# Altium schematic sheet 05 - ETHERNET, USB.SchDoc("05 - ETHERNET, USB")
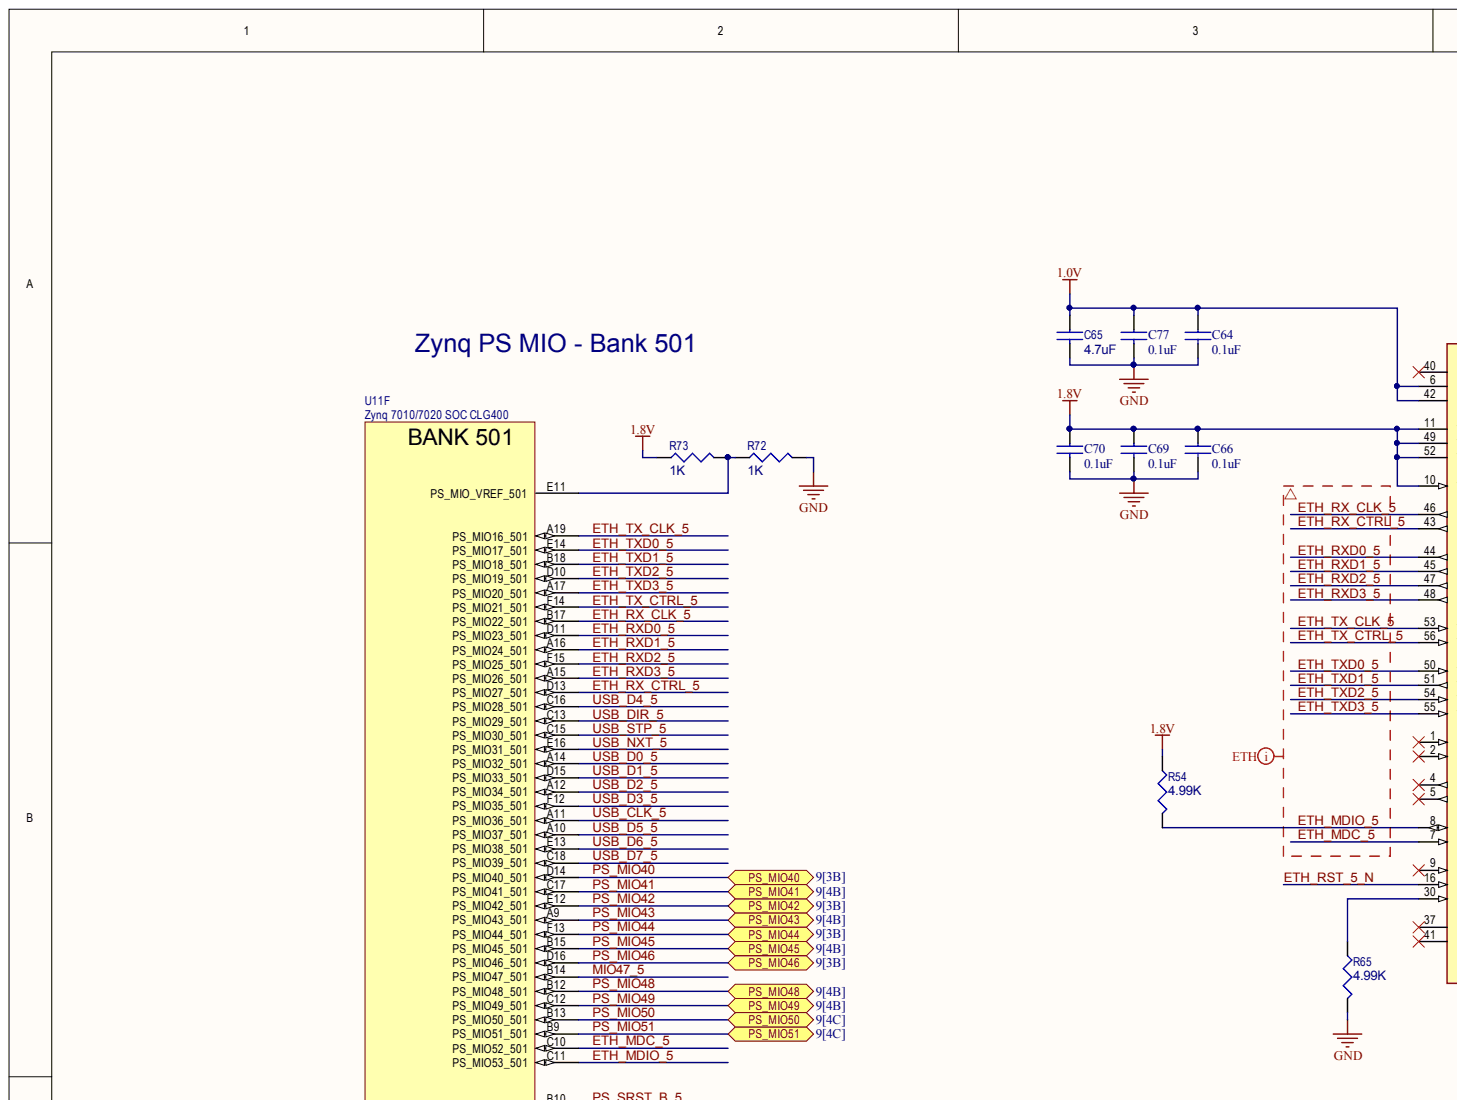
[diagram p0: 05 - ETHERNET, USB.SchDoc, top left]
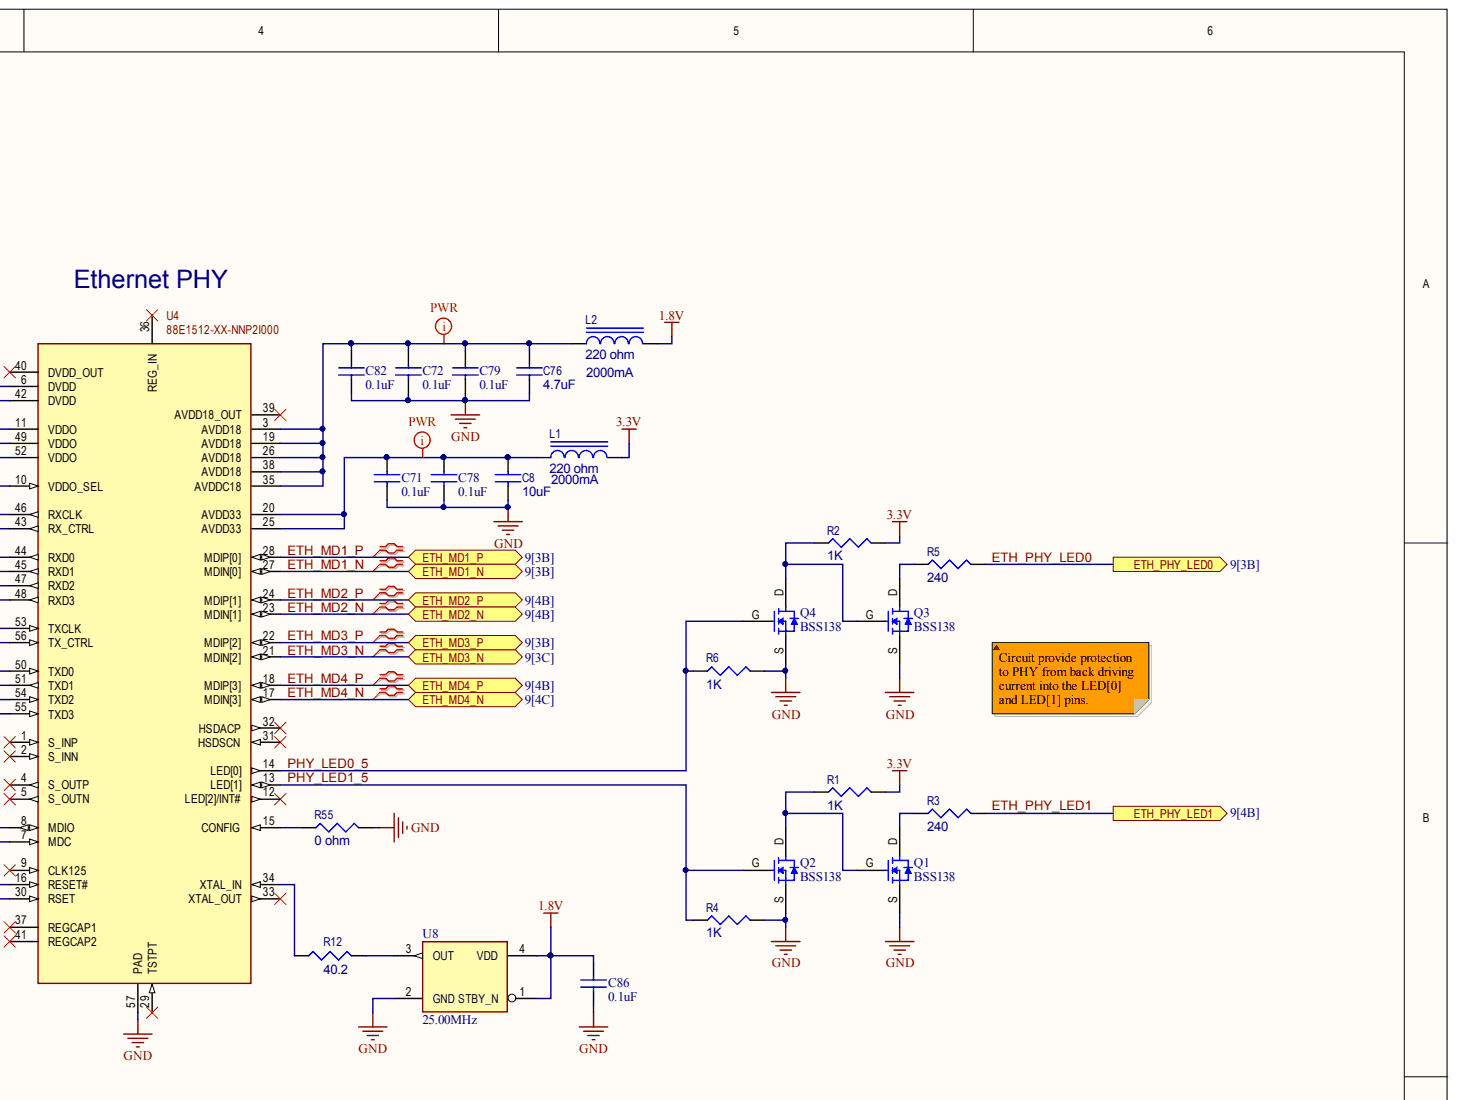
[diagram p1: 05 - ETHERNET, USB.SchDoc, top right]
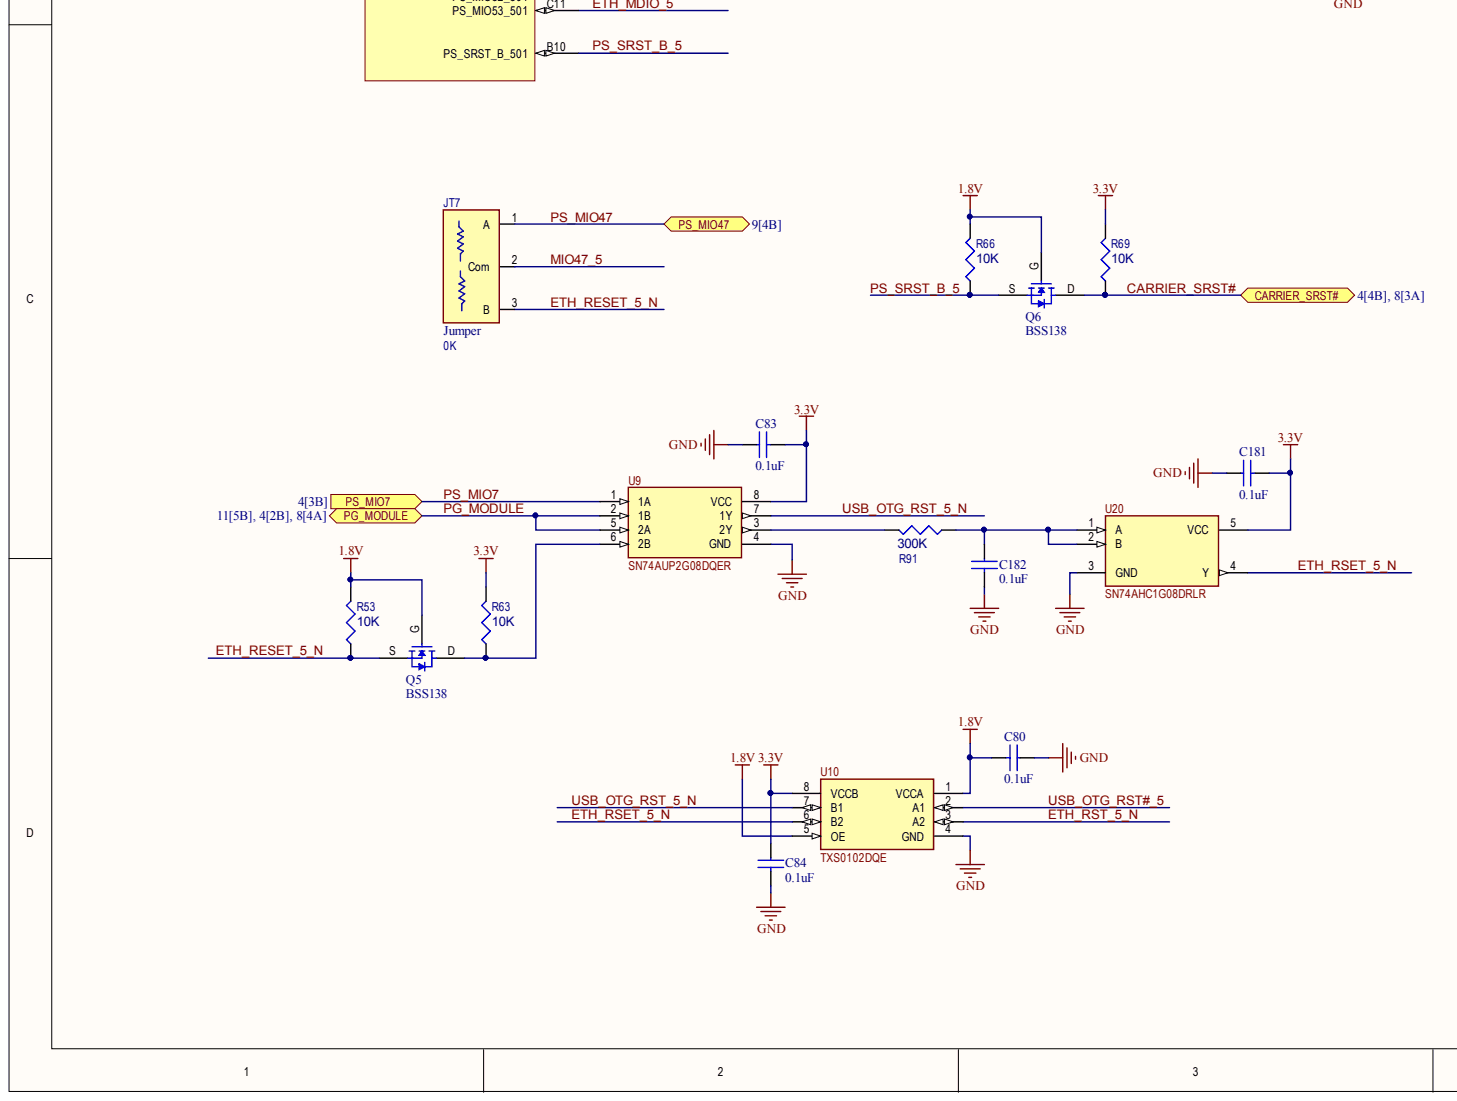
[diagram p2: 05 - ETHERNET, USB.SchDoc, bottom left]
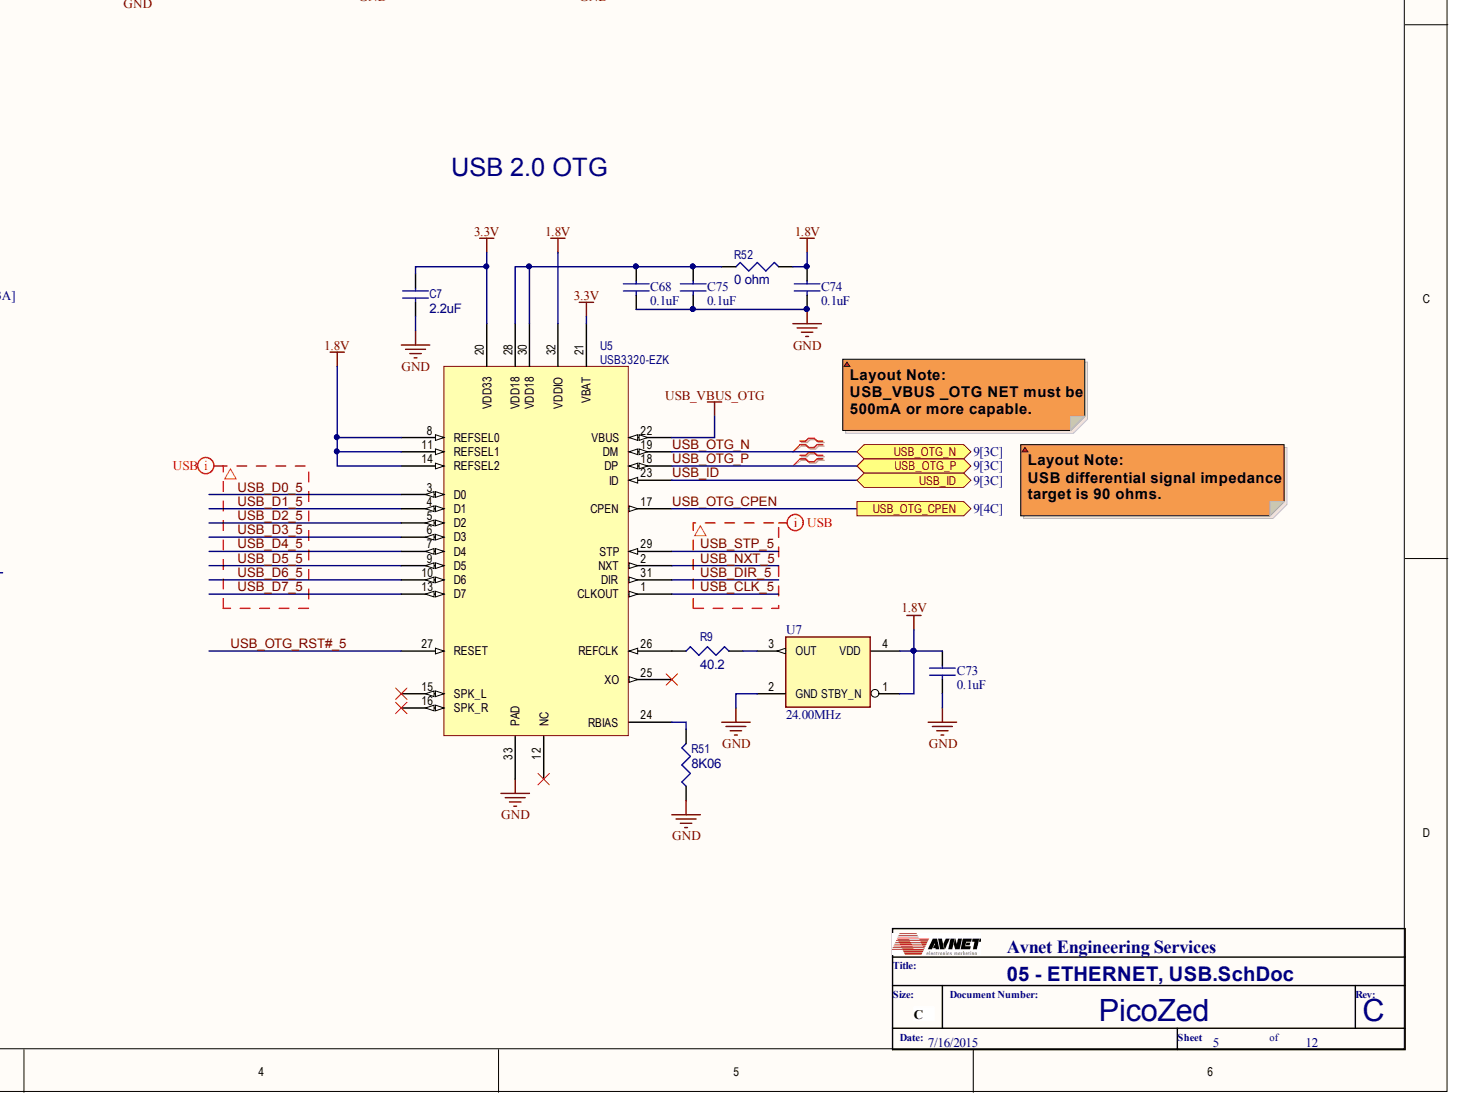
[diagram p3: 05 - ETHERNET, USB.SchDoc, bottom right]

## Parts
C7  2.2uF  [C0603]
C8  10uF  [C0603]
C64  value 0.1uF  [C0201]  (hidden comment: PC522)
C65  4.7uF  [C0402]
C66  value 0.1uF  [C0201]  (hidden comment: PC522)
C68  value 0.1uF  [C0201]  (hidden comment: PC522)
C69  value 0.1uF  [C0201]  (hidden comment: PC522)
C70  value 0.1uF  [C0201]  (hidden comment: PC522)
C71  value 0.1uF  [C0201]  (hidden comment: PC522)
C72  value 0.1uF  [C0201]  (hidden comment: PC522)
C73  value 0.1uF  [C0201]  (hidden comment: PC522)
C74  value 0.1uF  [C0201]  (hidden comment: PC522)
C75  value 0.1uF  [C0201]  (hidden comment: PC522)
C76  4.7uF  [C0402]
C77  value 0.1uF  [C0201]  (hidden comment: PC522)
C78  value 0.1uF  [C0201]  (hidden comment: PC522)
C79  value 0.1uF  [C0201]  (hidden comment: PC522)
C80  value 0.1uF  [C0201]  (hidden comment: PC522)
C82  value 0.1uF  [C0201]  (hidden comment: PC522)
C83  value 0.1uF  [C0201]  (hidden comment: PC522)
C84  value 0.1uF  [C0201]  (hidden comment: PC522)
C86  value 0.1uF  [C0201]  (hidden comment: PC522)
C181  value 0.1uF  [C0201]  (hidden comment: PC522)
C182  value 0.1uF  [C0201]  (hidden comment: PC522)
JT7  value 0K  Device: Jumper  [R0402-DUAL_JMP]
L1  value 220 ohm  Imax: 2000mA  [L0805]  (hidden comment: PF009)
L2  value 220 ohm  Imax: 2000mA  [L0805]  (hidden comment: PF009)
Q1  BSS138  [SOT23GSD]
Q2  BSS138  [SOT23GSD]
Q3  BSS138  [SOT23GSD]
Q4  BSS138  [SOT23GSD]
Q5  BSS138  [SOT23GSD]
Q6  BSS138  [SOT23GSD]
R1  1K  [R0402]
R2  1K  [R0402]
R3  240  [R0402]
R4  1K  [R0402]
R5  240  [R0402]
R6  1K  [R0402]
R9  40.2  [R0402]
R12  40.2  [R0402]
R51  8K06  [R0402]
R52  0 ohm  [R0402]
R53  10K  [R0402]
R54  4.99K  [R0402]
R55  0 ohm  [R0402]
R63  10K  [R0402]
R65  4.99K  [R0402]
R66  10K  [R0402]
R69  10K  [R0402]
R72  1K  [R0402]
R73  1K  [R0402]
R91  300K  [R0402]
U4  88E1512-XX-NNP2I000  [QFN56_14X14]
U5  USB3320-EZK  [QFN32]
U7  24.00MHz  [XTL_DSC1001-2.5X2.0]
U8  25.00MHz  [XTL_DSC1001-2.5X2.0]
U9  SN74AUP2G08DQER  [DQE8]
U10  TXS0102DQE  [DQE8]
U11  Zynq 7010/7020 SOC CLG400  [BGA400C80P20X20_1700X1700X160]  (part F)
U20  SN74AHC1G08DRLR  [SOT553]

## Ports
CARRIER_SRST#, ETH_MD1_N, ETH_MD1_P, ETH_MD2_N, ETH_MD2_P, ETH_MD3_N, ETH_MD3_P, ETH_MD4_N, ETH_MD4_P, ETH_PHY_LED0, ETH_PHY_LED1, PG_MODULE, PS_MIO7, PS_MIO40, PS_MIO41, PS_MIO42, PS_MIO43, PS_MIO44, PS_MIO45, PS_MIO46, PS_MIO47, PS_MIO48, PS_MIO49, PS_MIO50, PS_MIO51, USB_ID, USB_OTG_CPEN, USB_OTG_N, USB_OTG_P

## Nets
1.0V: C64.1 C65.1 C77.1 U4.6(DVDD) U4.42(DVDD)
1.8V: C66.1 C69.1 C70.1 C73.1 C74.1 C80.2 C86.1 L2.2 Q5.G Q6.G R52.2 R53.2 R54.1 R66.2 R73.2 U4.10(VDDO_SEL) U4.11(VDDO) U4.49(VDDO) U4.52(VDDO) U5.8(REFSEL0) U5.11(REFSEL1) U5.14(REFSEL2) U5.32(VDDIO) U7.1(STBY_N) U7.4(VDD) U8.1(STBY_N) U8.4(VDD) U10.1(VCCA) U10.5(OE)
3.3V: C7.1 C83.2 C84.1 C181.2 L1.2 R1.1 R2.1 R63.2 R69.2 U5.20(VDD33) U5.21(VBAT) U9.8(VCC) U10.8(VCCB) U20.5(VCC)
GND: C7.2 C8.2 C64.2 C65.2 C66.2 C68.2 C69.2 C70.2 C71.2 C72.2 C73.2 C74.2 C75.2 C76.2 C77.2 C78.2 C79.2 C80.1 C82.2 C83.1 C84.2 C86.2 C181.1 C182.2 Q1.S Q2.S Q3.S Q4.S R4.1 R6.1 R51.1 R55.1 R65.2 R72.1 U4.57(PAD) U5.33(PAD) U7.2(GND) U8.2(GND) U9.4(GND) U10.4(GND) U20.3(GND)
USB_VBUS_OTG: U5.22(VBUS)
CARRIER_SRST#: Q6.D R69.1 ; port CARRIER_SRST#
ETH_MD1_N: U4.27(MDIN[0]) ; port ETH_MD1_N
ETH_MD1_P: U4.28(MDIP[0]) ; port ETH_MD1_P
ETH_MD2_N: U4.23(MDIN[1]) ; port ETH_MD2_N
ETH_MD2_P: U4.24(MDIP[1]) ; port ETH_MD2_P
ETH_MD3_N: U4.21(MDIN[2]) ; port ETH_MD3_N
ETH_MD3_P: U4.22(MDIP[2]) ; port ETH_MD3_P
ETH_MD4_N: U4.17(MDIN[3]) ; port ETH_MD4_N
ETH_MD4_P: U4.18(MDIP[3]) ; port ETH_MD4_P
ETH_MDC_5: U4.7(MDC) U11.C10(PS_MIO52_501)  [also labelled ETH_MDC]
ETH_MDIO_5: R54.2 U4.8(MDIO) U11.C11(PS_MIO53_501)  [also labelled ETH_MDIO]
ETH_PHY_LED0: R5.2 ; port ETH_PHY_LED0
ETH_PHY_LED1: R3.2 ; port ETH_PHY_LED1
ETH_RESET_5_N: JT7.3 Q5.S R53.1  [also labelled ETH_RESET_N]
ETH_RSET_5_N: U10.6(B2) U20.4(Y)  [also labelled ETH_RSET_N]
ETH_RST_5_N: U4.16(RESET#) U10.3(A2)  [also labelled ETH_RST_N]
ETH_RX_CLK_5: U4.46(RXCLK) U11.B17(PS_MIO22_501)  [also labelled ETH_RX_CLK]
ETH_RX_CTRL_5: U4.43(RX_CTRL) U11.D13(PS_MIO27_501)  [also labelled ETH_RX_CTRL]
ETH_RXD0_5: U4.44(RXD0) U11.D11(PS_MIO23_501)  [also labelled ETH_RXD0]
ETH_RXD1_5: U4.45(RXD1) U11.A16(PS_MIO24_501)  [also labelled ETH_RXD1]
ETH_RXD2_5: U4.47(RXD2) U11.F15(PS_MIO25_501)  [also labelled ETH_RXD2]
ETH_RXD3_5: U4.48(RXD3) U11.A15(PS_MIO26_501)  [also labelled ETH_RXD3]
ETH_TX_CLK_5: U4.53(TXCLK) U11.A19(PS_MIO16_501)  [also labelled ETH_TX_CLK]
ETH_TX_CTRL_5: U4.56(TX_CTRL) U11.F14(PS_MIO21_501)  [also labelled ETH_TX_CTRL]
ETH_TXD0_5: U4.50(TXD0) U11.E14(PS_MIO17_501)  [also labelled ETH_TXD0]
ETH_TXD1_5: U4.51(TXD1) U11.B18(PS_MIO18_501)  [also labelled ETH_TXD1]
ETH_TXD2_5: U4.54(TXD2) U11.D10(PS_MIO19_501)  [also labelled ETH_TXD2]
ETH_TXD3_5: U4.55(TXD3) U11.A17(PS_MIO20_501)  [also labelled ETH_TXD3]
MIO47_5: JT7.2 U11.B14(PS_MIO47_501)  [also labelled MIO47]
PG_MODULE: U9.2(1B) U9.5(2A) ; port PG_MODULE
PHY_LED0_5: Q4.G R6.2 U4.14(LED[0])  [also labelled PHY_LED0]
PHY_LED1_5: Q2.G R4.2 U4.13(LED[1])  [also labelled PHY_LED1]
PS_MIO7: U9.1(1A) ; port PS_MIO7
PS_MIO40: U11.D14(PS_MIO40_501) ; port PS_MIO40
PS_MIO41: U11.C17(PS_MIO41_501) ; port PS_MIO41
PS_MIO42: U11.E12(PS_MIO42_501) ; port PS_MIO42
PS_MIO43: U11.A9(PS_MIO43_501) ; port PS_MIO43
PS_MIO44: U11.F13(PS_MIO44_501) ; port PS_MIO44
PS_MIO45: U11.B15(PS_MIO45_501) ; port PS_MIO45
PS_MIO46: U11.D16(PS_MIO46_501) ; port PS_MIO46
PS_MIO47: JT7.1 ; port PS_MIO47
PS_MIO48: U11.B12(PS_MIO48_501) ; port PS_MIO48
PS_MIO49: U11.C12(PS_MIO49_501) ; port PS_MIO49
PS_MIO50: U11.B13(PS_MIO50_501) ; port PS_MIO50
PS_MIO51: U11.B9(PS_MIO51_501) ; port PS_MIO51
PS_SRST_B_5: Q6.S R66.1 U11.B10(PS_SRST_B_501)  [also labelled PS_SRST_B]
USB_CLK_5: U5.1(CLKOUT) U11.A11(PS_MIO36_501)  [also labelled USB_CLK]
USB_D0_5: U5.3(D0) U11.A14(PS_MIO32_501)  [also labelled USB_D0]
USB_D1_5: U5.4(D1) U11.D15(PS_MIO33_501)  [also labelled USB_D1]
USB_D2_5: U5.5(D2) U11.A12(PS_MIO34_501)  [also labelled USB_D2]
USB_D3_5: U5.6(D3) U11.F12(PS_MIO35_501)  [also labelled USB_D3]
USB_D4_5: U5.7(D4) U11.C16(PS_MIO28_501)  [also labelled USB_D4]
USB_D5_5: U5.9(D5) U11.A10(PS_MIO37_501)  [also labelled USB_D5]
USB_D6_5: U5.10(D6) U11.E13(PS_MIO38_501)  [also labelled USB_D6]
USB_D7_5: U5.13(D7) U11.C18(PS_MIO39_501)  [also labelled USB_D7]
USB_DIR_5: U5.31(DIR) U11.C13(PS_MIO29_501)  [also labelled USB_DIR]
USB_ID: U5.23(ID) ; port USB_ID
USB_NXT_5: U5.2(NXT) U11.E16(PS_MIO31_501)  [also labelled USB_NXT]
USB_OTG_CPEN: U5.17(CPEN) ; port USB_OTG_CPEN
USB_OTG_N: U5.19(DM) ; port USB_OTG_N
USB_OTG_P: U5.18(DP) ; port USB_OTG_P
USB_OTG_RST#_5: U5.27(RESET) U10.2(A1)  [also labelled USB_OTG_RST#]
USB_OTG_RST_5_N: U9.7(1Y) U10.7(B1)  [also labelled USB_OTG_RST_N]
USB_STP_5: U5.29(STP) U11.C15(PS_MIO30_501)  [also labelled USB_STP]
NetC8_1: C8.1 C71.1 C78.1 L1.1 U4.20(AVDD33) U4.25(AVDD33)
NetC68_1: C68.1 C75.1 R52.1 U5.28(VDD18) U5.30(VDD18)
NetC72_1: C72.1 C76.1 C79.1 C82.1 L2.1 U4.3(AVDD18) U4.19(AVDD18) U4.26(AVDD18) U4.35(AVDDC18) U4.38(AVDD18)
NetC182_1: C182.1 R91.1 U20.1(A) U20.2(B)
NetQ1_D: Q1.D R3.1
NetQ1_G: Q1.G Q2.D R1.2
NetQ3_D: Q3.D R5.1
NetQ3_G: Q3.G Q4.D R2.2
NetQ5_D: Q5.D R63.1 U9.6(2B)
NetR9_1: R9.1 U5.26(REFCLK)
NetR9_2: R9.2 U7.3(OUT)
NetR12_1: R12.1 U4.34(XTAL_IN)
NetR12_2: R12.2 U8.3(OUT)
NetR51_2: R51.2 U5.24(RBIAS)
NetR55_2: R55.2 U4.15(CONFIG)
NetR65_1: R65.1 U4.30(RSET)
NetR72_2: R72.2 R73.1 U11.E11(PS_MIO_VREF_501)
NetR91_2: R91.2 U9.3(2Y)
N00209:
N00210:
N00211:

Not connected: U4 1(S_INP) 2(S_INN) 4(S_OUTP) 5(S_OUTN) 9(CLK125) 12(LED[2]/INT#) 29(TSTPT) 31(HSDSCN) 32(HSDACP) 33(XTAL_OUT) 36(REG_IN) 37(REGCAP1) 39(AVDD18_OUT) 40(DVDD_OUT) 41(REGCAP2); U5 12(NC) 15(SPK_L) 16(SPK_R) 25(XO)

## Library data of the parts
C7 = AVPC-0000155: CAP SM 2.2uF 6.3V 10% X5R C0603; PartCode: PC; AsyTxt: PC155; Database Table Name: Passive-Cap$; MFG Code: AVX; MFGPN: 06036D225KAT2A; Power: 0; Availability: 4K-AV; AVLNo: AVPC-0000155; Component Kind: Standard; ComponentLink1Description: Datasheet; ComponentLink2Description: MFR Website; ComponentLink2URL: www.avx.com; Cost: 0.04; Device: CAP; Dielectric: X5R; PkgType: SM; Received: 0; RoHS: Yes; Size: 3.4; Status: Preliminary; Tol: 10%; Value: 2.2uF; Volt: 6.3V; ComponentLink1URL: C:\AVDBLIB\DATASHEETS\AVX_CX5R.pdf; Library Name: Avnet_DBlib.DbLib
C8 = AVPC-0000086: CAP SM 10uF 6.3V 20% X5R C0603; PartCode: PC; AsyTxt: PC086; Database Table Name: Passive-Cap$; MFG Code: AVX; MFGPN: 06036D106MAT2A; AVLNo: AVPC-0000086; CLASSNAME: U11_BYP; Component Kind: Standard; ComponentLink1Description: Datasheet; Device: CAP; Dielectric: X5R; ESR: CAP; PkgType: SM; RoHS: Yes; Status: Preliminary; Tol: 20%; Value: 10uF; Volt: 6.3V; ComponentLink1URL: C:\AVDBLIB\DATASHEETS\AVX_CCOG.pdf; Library Name: Avnet_DBlib.DbLib
C64 C66 C68-C75 C77-C80 C82-C84 C86 C181 C182 = AVPC-0000522: CAP SM 0.1uF 10V 10% X5R C0201; PartCode: PC; Database Table Name: Passive-Cap$; MFG Code: AVX; MFGPN: 02016D104KAT2A; AsyTxt: PC522; AVLNo: AVPC-0000522; Component Kind: Standard; ComponentLink1Description: Datasheet; Device: CAP; Dielectric: X5R; PkgType: SM; RoHS: Yes; Status: Preliminary; Tol: 10%; Volt: 10V; ComponentLink1URL: C:\AVDBLIB\DATASHEETS\AVX_CCOG.pdf; Library Name: Avnet_DBlib.DbLib
  C64 C66 C69-C72 C77-C79 C82: CLASSNAME: U11_BYP
C65 C76 = AVPC-0000499: CAP SM 4.7uF 6.3V 20% X5R C0402; PartCode: PC; AsyTxt: PC499; Database Table Name: Passive-Cap$; MFG Code: AVX; MFGPN: GRM155R60J475ME87D; Power: 0; Availability: 140K-DK; AVLNo: AVPC-0000499; CLASSNAME: U11_BYP; Component Kind: Standard; ComponentLink1Description: Datasheet; Cost: .46; Device: CAP; Dielectric: X5R; PkgType: SM; Received: 0; RoHS: Yes; Size: 1.9; Status: Preliminary; Tol: 20%; Value: 4.7uF; Volt: 6.3V; ComponentLink1URL: C:\AVDBLIB\DATASHEETS\murata_caps_c02e.pdf; Library Name: Avnet_DBlib.DbLib
JT7 = AVPO-0000025: Jumper 3-pad 0402 RES - 0 Ohm : Pads 1-2; PartCode: PO; Database Table Name: Passive-Other$; Device Type: 3-pad 0R0 0402; MFG Code: DAL; MFGPN: CRCW04020000Z0ED; Note: Place Pads: 1-2; PkgType: SM; Component Kind: Standard; ComponentLink1Description: Datasheet; Part #: CRCW04020000Z0ED; RoHS: Yes; Status: Preliminary; ComponentLink1URL: C:\AVDBLIB\DATASHEETS\Dale_CRCW.pd; Library Name: Avnet_DBlib.DbLib
L1 L2 = AVPF-0000009: FB SM 220 ohm@100MHz 2000mA 0.25 0.045 ohm@DC L0805; PartCode: PF; Database Table Name: Passive-Ferrite Bead$; MFG Code: MUR; MFGPN: BLM21PG221SN1D; Test Freq: 100MHz; Tol: 0.25; AsyTxt: PF009; AVLNo: AVPF-0000009; CLASSNAME: U11_BYP; Component Kind: Standard; ComponentLink1Description: Datasheet; DCR: 0.045 ohm; Device: FB; PkgType: SM; RoHS: Yes; Status: Preliminary; ComponentLink1URL: C:\AVDBLIB\DATASHEETS\L0110S0100BLM21P.pdf; Library Name: Avnet_DBlib.DbLib
Q1-Q4 Q6 = MOSFET-N: MFG#1/Part#: OnSemi: BSS138LT1G; MFG: On Semi; MFGPN: BSS138LT1G; Component Kind: Standard; Description: MOSFET-N; MFG Code: ON SEMI; Library Name: HKADS_Lib.IntLib
Q5 = MOSFET-N: MFG#1/Part#: OnSemi: BSS138LT1G; MFG Code: ON SEMI; MFGPN: BSS138LT1G; Component Kind: Standard; Description: MOSFET-N; MFG Code: ON Semi; Library Name: HKADS_Lib.IntLib
R1 R2 R4 R6 R72 R73 = AVPR-0000052: RES SM 1K 62mW 0.01 R0402; PartCode: PR; AsyTxt: PR052; Database Table Name: Passive-Res$; MFG Code: DAL; MFGPN: CRCW04021K00FKED; Tol: 0.01; AVLNo: AVPR-0000052; Component Kind: Standard; ComponentLink1Description: Datasheet; Device: RES; PkgType: SM; RoHS: Yes; Status: Preliminary; Value: 1K; Watt: 62mW; ComponentLink1URL: C:\AVDBLIB\DATASHEETS\Dale_CRCW.pd; Library Name: Avnet_DBlib.DbLib
R3 R5 = AVPR-0000511: RES SM 240 62mW 0.01 R0402; Alt1MFR: NIC; PartCode: PR; Alt1PN: NRC04F2400TRF; AsyTxt: PR511; Database Table Name: Passive-Res$; MFG Code: DAL; MFGPN: CRCW0402240RFKED; Power: 0; Tol: 0.01; Availability: 1M-AV; AVLNo: AVPR-0000511; Component Kind: Standard; ComponentLink1Description: Datasheet; Cost: .01; Device: RES; PkgType: SM; Received: 0; RoHS: Yes; Size: 1.9; Status: Preliminary; Value: 240; Watt: 62mW; ComponentLink1URL: C:\AVDBLIB\DATASHEETS\Dale_CRCW.pd; Library Name: Avnet_DBlib.DbLib
R9 R12 = (no symbol name): RES SM 40.2 62mW 0.01 R0402; PartCode: PR; AsyTxt: PR092; MFG Code: DAL; MFGPN: CRCW040240R2FKED; Power: 0; Tol: 0.01; Watt: 63mW; Availability: 2M-AV; CLASSNAME: DDR_TERMR; Component Kind: Standard; ComponentLink1Description: Datasheet; Cost: .01; Device: RES; Library Name: Z7045_MM.SchLib; PkgType: SM; Received: 0; RoHS: Yes; Size: 1.9; Status: Preliminary; Value: 40.2; Watt: 62mW; ComponentLink1URL: C:\AVDBLIB\DATASHEETS\Dale_CRCW.pd
R51 = (no symbol name): RES SM 8.06k 62mW 0.01 R0402; PartCode: PR; AsyTxt: PR092; AVLNo: AVPR-0000561; MFG Code: DAL; MFGPN: CRCW04028K06FKED; Power: 0; Tol: 0.01; Watt: 63mW; Availability: 2M-AV; Component Kind: Standard; ComponentLink1Description: Datasheet; Cost: .01; Device: RES; Library Name: Z7045_MM.SchLib; PkgType: SM; Received: 0; RoHS: Yes; Size: 1.9; Status: Preliminary; Value: 8K06; Watt: 62mW; ComponentLink1URL: C:\AVDBLIB\DATASHEETS\Dale_CRCW.pd
R52 R55 = AVPR-0000027: RES SM 0 ohm 62mW J R0402; PartCode: PR; AsyTxt: PR027; Database Table Name: Passive-Res$; MFG Code: DAL; MFGPN: CRCW04020000Z0ED; AVLNo: AVPR-0000027; Component Kind: Standard; ComponentLink1Description: Datasheet; Device: RES; PkgType: SM; RoHS: Yes; Status: Preliminary; Value: 0 ohm; Watt: 62mW; ComponentLink1URL: C:\AVDBLIB\DATASHEETS\Dale_CRCW.pd; Library Name: Avnet_DBlib.DbLib
R53 R63 R66 R69 = AVPR-0000034: RES SM 10.0K 62mW 0.01 R0402; PartCode: PR; AsyTxt: PR034; Database Table Name: Passive-Res$; MFG Code: DAL; MFGPN: CRCW040210K0FKED; Power: 0; Tol: 0.01; Watt: 63mW; Availability: 2M-AV; AVLNo: AVPR-0000034; CLASSNAME: DDR_TERM; Component Kind: Standard; ComponentLink1Description: Datasheet; Cost: .01; Device: RES; PkgType: SM; Received: 0; RoHS: Yes; Size: 1.9; Status: Preliminary; Value: 10K; Watt: 62mW; ComponentLink1URL: C:\AVDBLIB\DATASHEETS\Dale_CRCW.pd; Library Name: Avnet_DBlib.DbLib
R54 R65 = AVPR-0000094: RES SM 4.99K 62mW 0.01 R0402; PartCode: PR; AsyTxt: PR094; Database Table Name: Passive-Res$; MFG Code: DAL; MFGPN: CRCW04024K99FKED; Tol: 0.01; AVLNo: AVPR-0000094; Component Kind: Standard; ComponentLink1Description: Datasheet; Device: RES; PkgType: SM; RoHS: Yes; Status: Preliminary; Value: 4.99K; Watt: 62mW; ComponentLink1URL: C:\AVDBLIB\DATASHEETS\Dale_CRCW.pd; Library Name: Avnet_DBlib.DbLib
R91 = AVPR-0000034: RES SM 300.0K 62mW 0.01 R0402; Database Table Name: Passive-Res$; MFG Code: DAL; MFGPN: CRCW0402300KFKED; Watt: 63mW; Component Kind: Standard; Device: RES; Value: 300K; Library Name: Avnet_DBlib.DbLib
U4 = 88E1512: High Integrated 10/100/1000 Ultra Gigabit Ethernet Tranceiver; AVLNo: AVIY-0000047; MFG Code: MARVELL; MFGPN: 88E1512-A0-NNP2C000; Component Kind: Standard; Library Name: Zynq_Z010_ZedStick.SchLib
U5 = USB3320C-EZK: USB 2.0 ULPI OTG Tranceiver; AVLNo: AVIY-0000045; MFG Code: SMSC; Component Kind: Standard; Library Name: Z7045_MM.SchLib; MFGPN: USB3320C-EZK
U7 = DSC1001DI1-024.0000: OSC, SM, Abracon, 1.8V, 24.0000MHz, 50ppm, 2.5x2.0mm; AVLNo: AVIT-0000120; MFGPN: ASDMB-24.000MHZ-LC-T; Component Kind: Standard; Library Name: Zynq_Z010_ZedStick.SchLib; MFG Code: ABRACON
U8 = DSC1001DI1-025.0000: OSC, SM, Abracon,1.8V, 25.0000MHz, 50ppm, 2.5x2.0mm; AVLNo: AVIT-0000121; MFGPN: ASDMB-25.000MHZ-LC-T; Component Kind: Standard; Library Name: Zynq_Z010_ZedStick.SchLib; MFG Code: ABRACON
U9 = (no symbol name): Low Power Dual 2-Input Positive-AND Gate; MFG Code: TIS; MFGCODE: Texas Instruments; Component Kind: Standard; Library Name: new.SchLib; MFGPN: SN74AUP2G08DQER; RoHS: Yes; Status: Preliminary
U10 = TXS0102: 2-Bit Bidirectional Voltage-Level Translator; PartCode: ID; AsyTxt: ID486; AVLNo: AVID-0000486; Bits: 2bit; MFG Code: TIS; PkgType: SM; Ports/Channels: 2ch Bidir; CLASSNAME: FINEPITCH; Component Kind: Standard; Device: TXS0102; Device Type: TRANSLATOR; Library Name: new.SchLib; MFGCODE: TIS; MFGPN: TXS0102DQE; RoHS: Yes; Status: Preliminary; Technology: LVCMOS
U11 = Zynq Z010/Z020 SOC CLG400: MFG Code: XLX; MFGPN: XC7Z010-1CLG400C; CLASSNAME: BGA; Component Kind: Standard; Description: Zynq 7010/7020 SOC CLG400; Library Name: Zynq_Z010_ZedStick.SchLib
U20 = (no symbol name): Low Power Single 2-Input Positive-AND Gate; MFG Code: TIS; Component Kind: Standard; Library Name: new.SchLib; MFGCODE: TIS; MFGPN: SN74AHC1G08DRLR; RoHS: Yes; Status: Preliminary
